# Altium schematic sheet Sheet3.SchDoc(Sheet3)
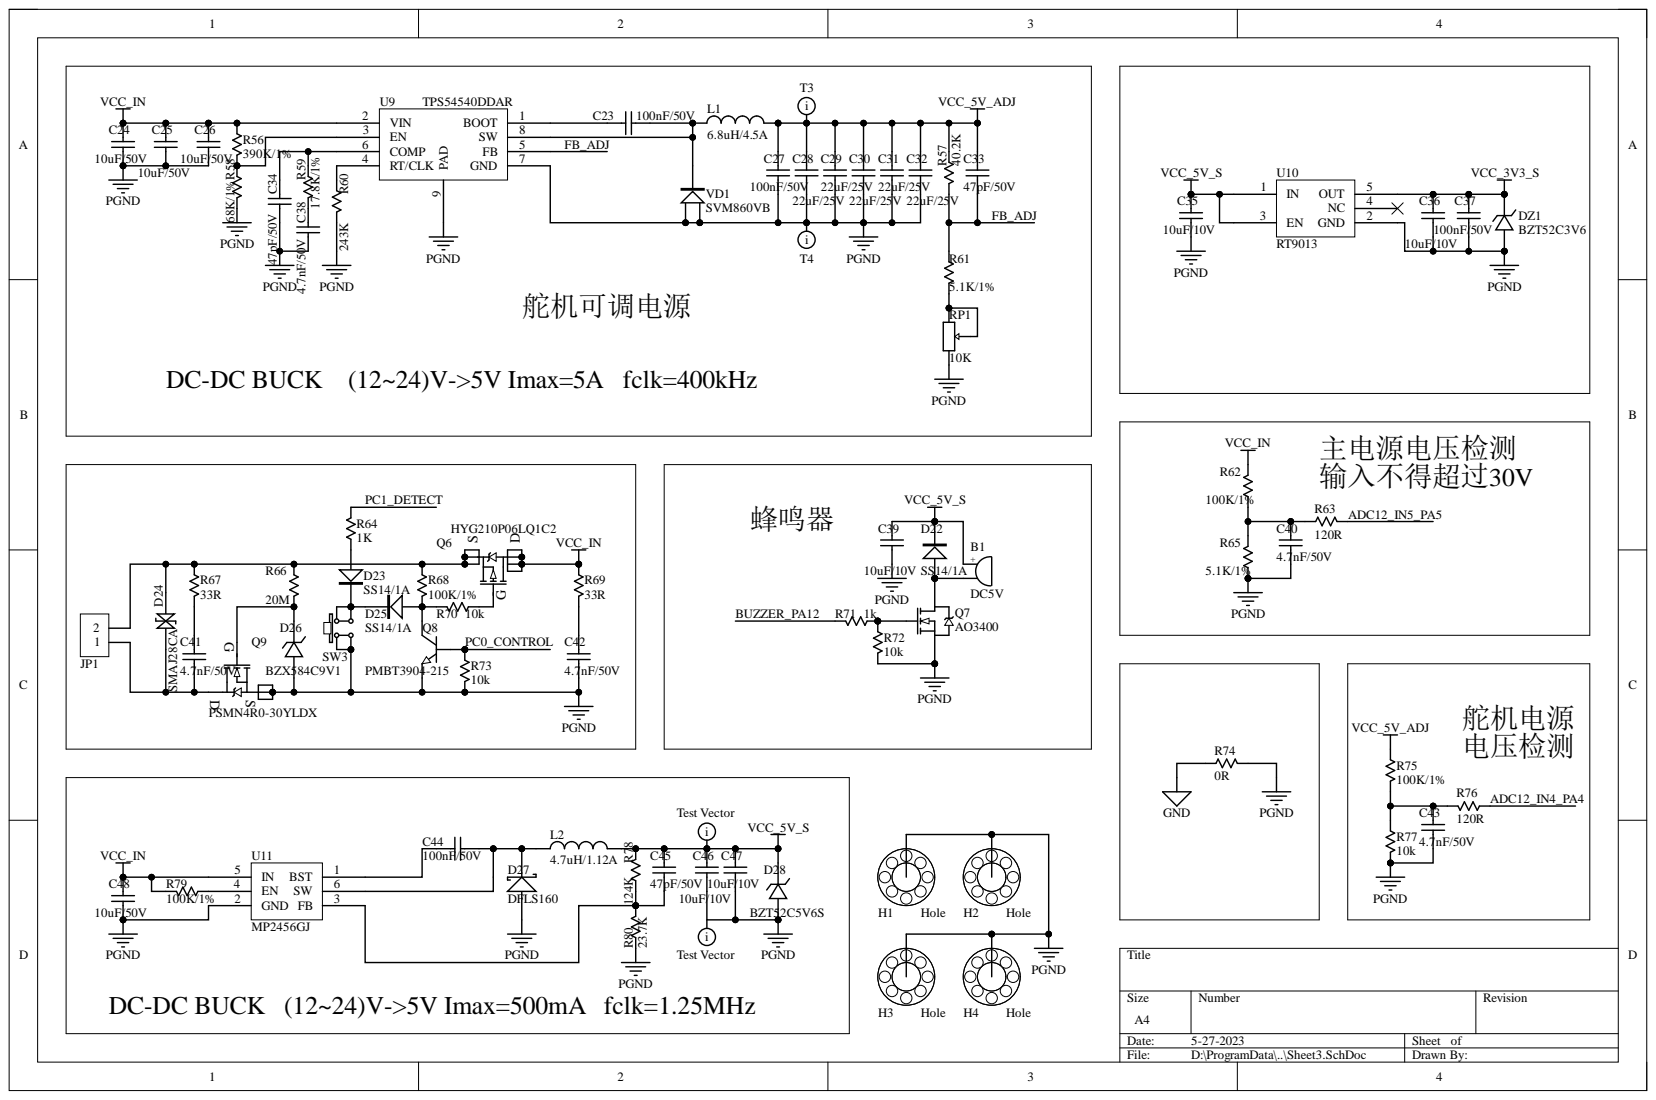
[diagram p0: Sheet3.SchDoc]

## Parts
B1  DC5V  [KLJ-8530-5027]
C23  100nF/50V  [C 0402]
C24  10uF/50V  [C 0805]
C25  10uF/50V  [C 0805]
C26  10uF/50V  [C 0805]
C27  100nF/50V  [C 0402]
C28  22uF/25V  [C 0805]
C29  22uF/25V  [C 0805]
C30  22uF/25V  [C 0805]
C31  22uF/25V  [C 0805]
C32  22uF/25V  [C 0805]
C33  47pF/50V  [C 0402]
C34  47pF/50V  [C 0402]
C35  10uF/10V  [C 0402]
C36  10uF/10V  [C 0402]
C37  100nF/50V  [C 0402]
C38  4.7nF/50V  [C 0402]
C39  10uF/10V  [C 0402]
C40  4.7nF/50V  [C 0402]
C41  4.7nF/50V  [C 0402]
C42  4.7nF/50V  [C 0402]
C43  4.7nF/50V  [C 0402]
C44  100nF/50V  [C 0402]
C45  47pF/50V  [C 0402]
C46  10uF/10V  [C 0402]
C47  10uF/10V  [C 0402]
C48  10uF/50V  [C 0805]
D22  SS14/1A  [SOD-323]
D23  SS14/1A  [SOD-323]
D24  SMAJ28CA  [SMA]
D25  SS14/1A  [SOD-323]
D26  BZX584C9V1  [SOD-523]
D27  DFLS160  [SOD-123]
D28  BZT52C5V6S  [SOD-323]
DZ1  BZT52C3V6  [SOD-323]
H1  Hole  [M3 125X300]
H2  Hole  [M3 125X300]
H3  Hole  [M3 125X300]
H4  Hole  [M3 125X300]
JP1  HDR-1X2  [KF301-2P]  (value not drawn)
L1  6.8uH/4.5A  [L-SMD-0630]
L2  4.7uH/1.12A  [L2520]
Q6  HYG210P06LQ1C2  [DFN-5X6-8L]
Q7  AO3400  [SOT23-3N-AO3400]
Q8  PMBT3904-215  [SOT23-3N]
Q9  PSMN4R0-30YLDX  [SOT-669]
R56  390K/1%  [R 0402]
R57  40.2K  [R 0402]
R58  68K/1%  [R 0402]
R59  17.8K/1%  [R 0402]
R60  243K  [R 0402]
R61  5.1K/1%  [R 0402]
R62  100K/1%  [R 0402]
R63  120R  [R 0402]
R64  1K  [R 0402]
R65  5.1K/1%  [R 0402]
R66  20M  [R 0603]
R67  33R  [R 0402]
R68  100K/1%  [R 0402]
R69  33R  [R 0402]
R70  10k  [R 0402]
R71  1k  [R 0402]
R72  10k  [R 0402]
R73  10k  [R 0402]
R74  0R  [R 0805]
R75  100K/1%  [R 0402]
R76  120R  [R 0402]
R77  10k  [R 0402]
R78  124K  [R 0402]
R79  100K/1%  [R 0402]
R80  23.7K  [R 0402]
RP1  10K  [3303W]
SW3  6x6x5  [TS-A016]  (value not drawn)
U9  TPS54540DDAR  [TPS54540]
U10  RT9013  [SOT23-5N]
U11  MP2456GJ  [MP2456]
VD1  SVM860VB  [TO-277B]

## Nets
GND: R74.2
PGND: C24.1 C25.1 C26.1 C27.1 C28.1 C29.1 C30.1 C31.1 C32.1 C34.1 C35.1 C36.1 C37.1 C38.1 C39.1 C40.1 C42.1 C43.1 C46.1 C47.1 C48.1 D26.1 D27.1 D28.1 DZ1.1 H1.1 H2.1 H3.1 H4.1 Q7.3 Q8.2 Q9.1 Q9.2 Q9.3 R58.1 R60.1 R65.1 R72.1 R73.1 R74.1 R77.1 R80.2 RP1.3 SW3.1 SW3.4 U9.7(GND) U9.9(PAD) U10.2(GND) U11.2(GND) VD1.1 VD1.2
VCC_3V3_S: C36.2 C37.2 DZ1.2 U10.5(OUT)
VCC_5V_ADJ: C27.2 C28.2 C29.2 C30.2 C31.2 C32.2 C33.2 L1.2 R57.2 R75.1
VCC_5V_S: B1.1 C35.2 C39.2 C45.2 C46.2 C47.2 D22.2 D28.2 L2.2 R78.1 U10.1(IN) U10.3(EN)
VCC_IN: C24.2 C25.2 C26.2 C48.2 Q6.5 Q6.6 Q6.7 Q6.8 R56.2 R62.2 R69.2 R79.2 U9.2(VIN) U11.5(IN)
ADC12_IN4_PA4: R76.2
ADC12_IN5_PA5: R63.1
BUZZER_PA12: R71.1
FB_ADJ: C33.1 R57.1 R61.2 U9.5(FB)
PC0_CONTROL: Q8.1 R73.2
PC1_DETECT: R64.2
NetB1_2: B1.2 D22.1 Q7.2
NetC23_1: C23.1 L1.1 U9.8(SW) VD1.3
NetC23_2: C23.2 U9.1(BOOT)
NetC34_2: C34.2 R59.2 U9.6(COMP)
NetC38_2: C38.2 R59.1
NetC40_2: C40.2 R62.1 R63.2 R65.2
NetC41_1: C41.1 D24.2 JP1.1 Q9.5
NetC41_2: C41.2 R67.1
NetC42_2: C42.2 R69.1
NetC43_2: C43.2 R75.2 R76.1 R77.2
NetC44_1: C44.1 D27.2 L2.1 U11.6(SW)
NetC44_2: C44.2 U11.1(BST)
NetC45_1: C45.1 R78.2 R80.1 U11.3(FB)
NetD23_1: D23.1 R64.1
NetD23_2: D23.2 D25.2 SW3.2 SW3.3
NetD24_1: D24.1 JP1.2 Q6.1 Q6.2 Q6.3 R66.2 R67.2 R68.1
NetD25_1: D25.1 Q8.3 R68.2 R70.1
NetD26_2: D26.2 Q9.4 R66.1
NetQ6_4: Q6.4 R70.2
NetQ7_1: Q7.1 R71.2 R72.2
NetR56_1: R56.1 R58.2 U9.3(EN)
NetR60_2: R60.2 U9.4(RT/CLK)
NetR61_1: R61.1 RP1.1 RP1.2
NetR79_1: R79.1 U11.4(EN)

Not connected: U10 4(NC)

## Library data of the parts
B1 = BEEP: 蜂鸣器; 版权归属: 黎明电子style; BOM名称: 蜂鸣器; Component Kind: Standard; Library Name: 未分类器件.SchLib; 学习资源: https://lmdzstyle.taobao.com/
C23-C48 = CAP: Capacitor; Component Kind: Standard; Library Name: Passive Components Class.SchLib
D22 D23 D25 = 1N4007: 整流二极管; 版权归属: 黎明电子style; BOM名称: 整流二极管; Component Kind: Standard; Library Name: 二极管-整流桥.SchLib; 学习资源: https://lmdzstyle.taobao.com/
D24 = DR: 瞬态电压抑制二极管; 版权归属: 黎明电子style; BOM名称: 瞬态电压抑制二极管; Component Kind: Standard; Library Name: 二极管-整流桥.SchLib; 学习资源: https://lmdzstyle.taobao.com/
D26 D28 DZ1 = 1N4106: 12V/0.5W稳压管; 版权归属: 黎明电子style; BOM名称: 12V/0.5W稳压管; Component Kind: Standard; Library Name: 二极管-整流桥.SchLib; 学习资源: https://lmdzstyle.taobao.com/
D27 = D Schottky: Schottky Diode; Code_JEDEC: DO-214-AA; Component Kind: Standard; LatestRevisionDate: 17-Jul-2002; LatestRevisionNote: Re-released for DXP Platform.; Library Name: Miscellaneous Devices.IntLib; PackageDescription: DO-214-AA/SMB; 2 C-Bend Leads; Body 5.3 x 3.6 mm, inc. leads (LxW); PackageReference: SMB; Published: 8-Jun-2000; Signal Integrity: D Schottky; Simulation: SKYDIODE; Publisher: Altium Limited
H1-H4 = Hole: Mounting holes; Component Kind: Standard; Library Name: FX Class.SchLib
JP1 = Header 2: 2P接插件; 版权归属: 黎明电子style; BOM名称: 2P接插件; Component Kind: Standard; Library Name: 接插件.SchLib; 学习资源: https://lmdzstyle.taobao.com/
L1 L2 = Inductor: Code_IEC: 1005; Value: 6.8uH; Code_JEITA: 0402; Component Kind: Standard; Description: Inductor; LatestRevisionDate: 29-May-2009; LatestRevisionNote: IPC-7351 Footprint Added.; Library Name: Miscellaneous Devices.IntLib; PackageDescription: Chip Inductor; PackageReference: 0402-A; Published: 8-Jun-2000; Signal Integrity: Inductor; Simulation: INDUCTOR; Publisher: Altium Limited
Q6 = TPCA8122: Component Kind: Standard; Description: TPCA8122; Library Name: 场效应管-可控硅.SchLib
Q7 = NMOS-2: N-Channel Power MOSFET; Note: Pin 2 is electrically connected to pin 4.; Component Kind: Standard; ComponentLink1Description: Manufacturer Link; ComponentLink1URL: http://www.irf.com/; DatasheetVersion: PD-9.814; LatestRevisionDate: 17-Jul-2002; LatestRevisionNote: Re-released for DXP Platform.; Library Name: Miscellaneous Devices.IntLib; PackageDescription: TO, Thru-Hole, Vertical, Heatsink Mounted; 3 In-Line Leads; Pitch 2.54 mm; PackageReference: TO-220AB; PackageVersion: Feb-1997; Published: 8-Jun-2000; Simulation: IRF1010; Publisher: Altium Limited
Q8 = 8050-SMD: 高频放大-NPN型; 属性: 40V 1.5A 1W 100MHZ; 版权归属: 黎明电子style; BOM名称: 高频放大-NPN型; Component Kind: Standard; Library Name: 三极管.SchLib; 学习资源: https://lmdzstyle.taobao.com/
Q9 = PSMN1R4-40YLD: PSMN1R8-40YLC; Component Kind: Standard; Library Name: 场效应管-可控硅.SchLib
R56-R80 = RES: Resistor; Component Kind: Standard; Library Name: Passive Components Class.SchLib
RP1 = RP-SMD: 贴片电位器; 版权归属: 黎明电子style; BOM名称: 贴片电位器; Component Kind: Standard; Library Name: 电阻-电容-电感.SchLib; 学习资源: https://lmdzstyle.taobao.com/
SW3 = TSW SMD-6x6: 贴片6x6轻触开关; 版权归属: 黎明电子style; BOM名称: 贴片6x6轻触开关; Component Kind: Standard; Library Name: 按键-开关.SchLib; 学习资源: https://lmdzstyle.taobao.com/
VD1 = SVM860VB: 整流二极管; 版权归属: 黎明电子style; BOM名称: 整流二极管; Component Kind: Standard; Library Name: 二极管-整流桥.SchLib; 学习资源: https://lmdzstyle.taobao.com/
U9 = TPS54540DDAR: Component Kind: Standard; Description: TPS54540DDAR; Library Name: IC芯片.SchLib
U10 = RT9013: Component Kind: Standard; Description: RT9013; Library Name: IC芯片.SchLib
U11 = MP2456GJ: Component Kind: Standard; Description: MP2456GJ; Library Name: IC芯片.SchLib
